# Altium schematic sheet [12]-Valve-Control.SchDoc
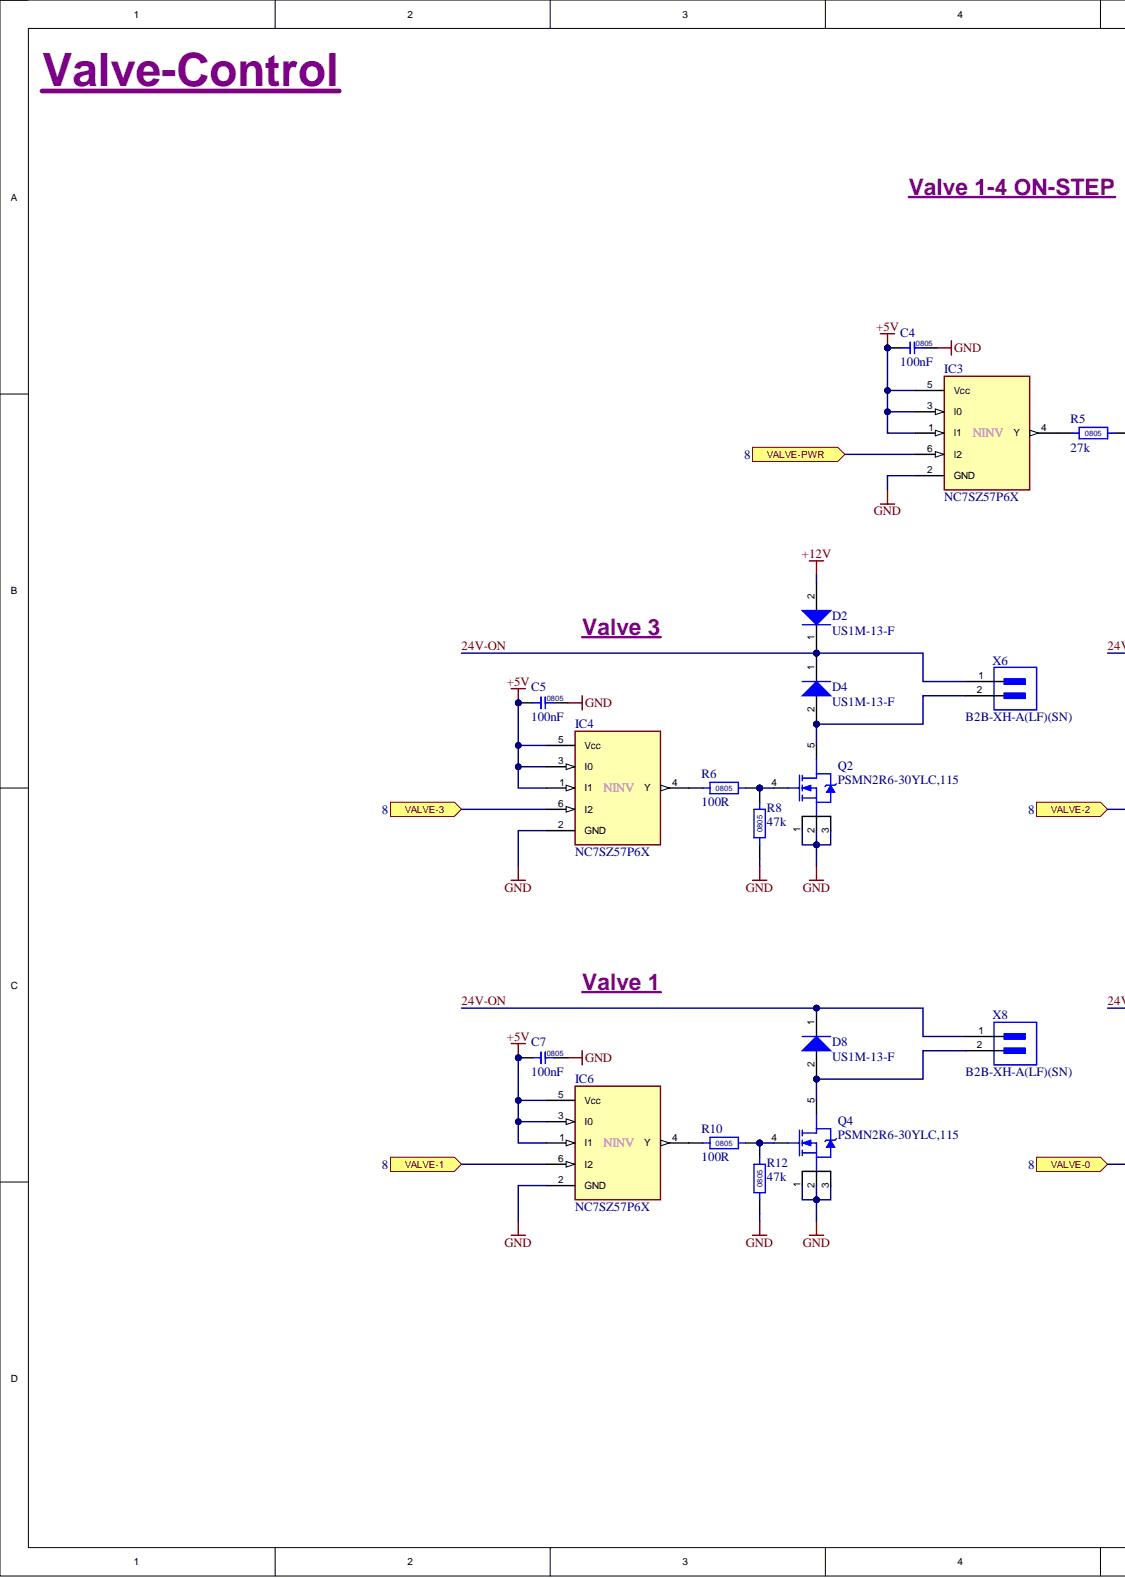
[diagram p0: [12]-Valve-Control.SchDoc, left]
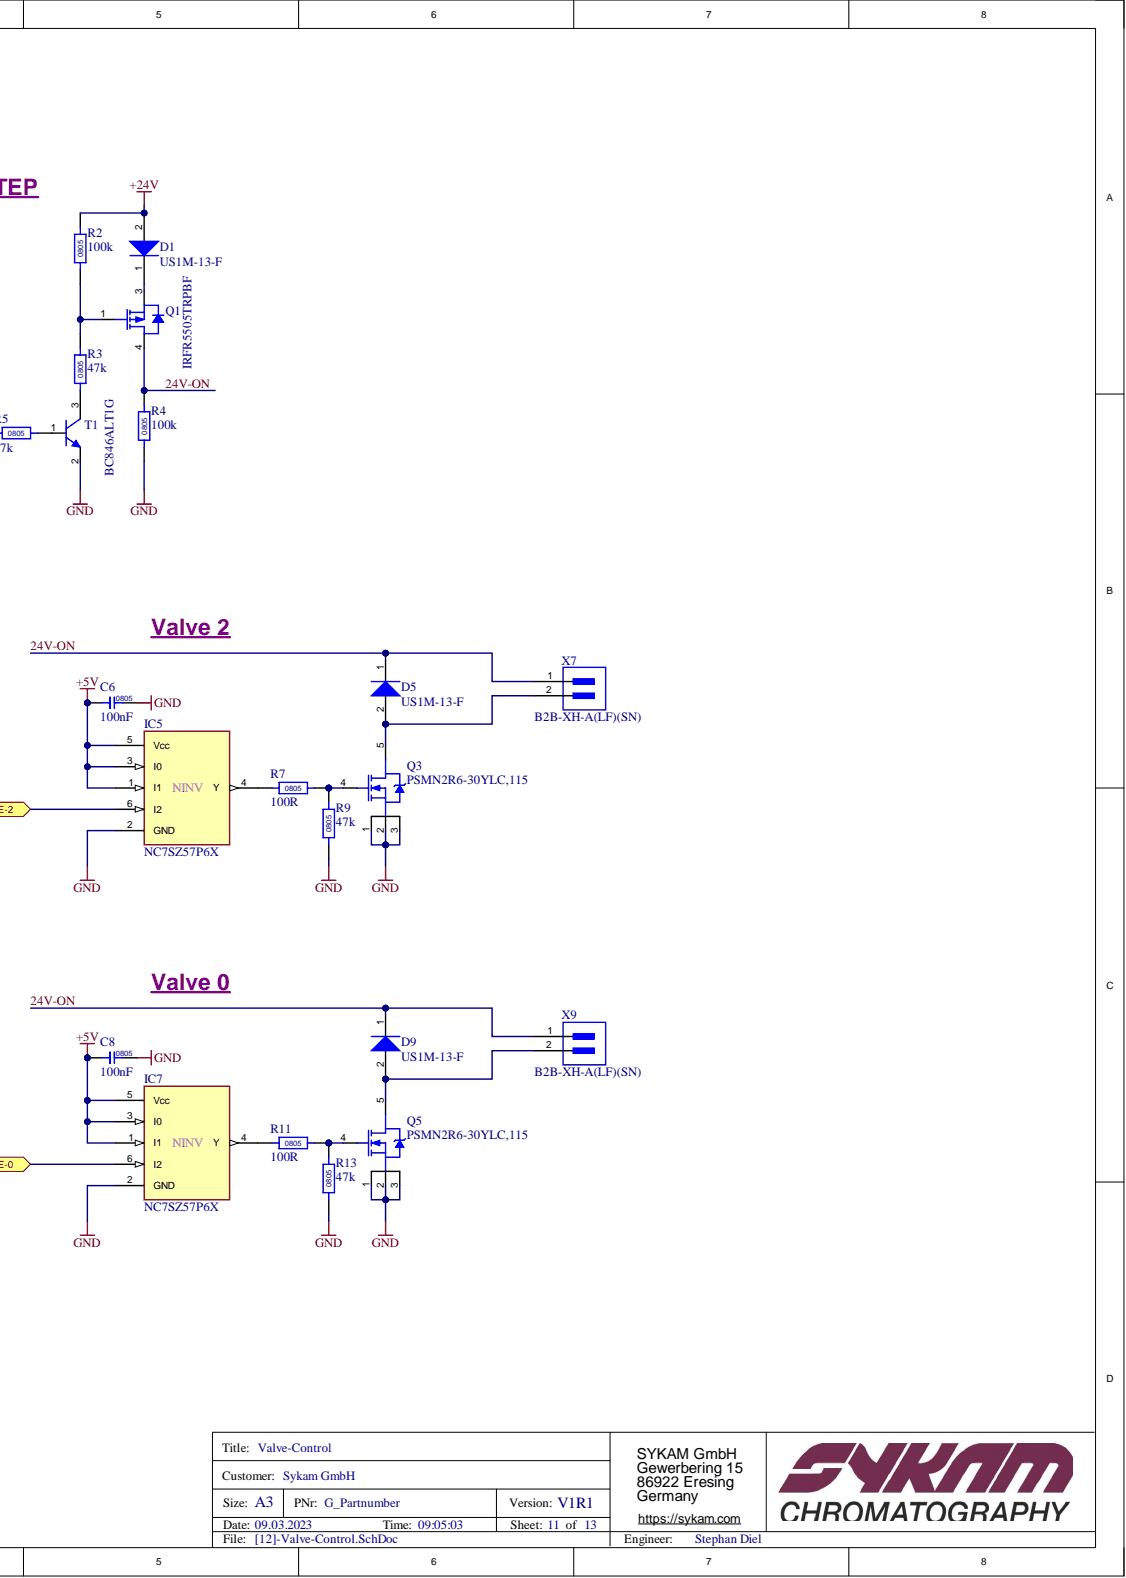
[diagram p1: [12]-Valve-Control.SchDoc, right]

## Parts
C4  100nF  Case Code (Imperial): 0805  [C0805]
C5  100nF  Case Code (Imperial): 0805  [C0805]
C6  100nF  Case Code (Imperial): 0805  [C0805]
C7  100nF  Case Code (Imperial): 0805  [C0805]
C8  100nF  Case Code (Imperial): 0805  [C0805]
D1  US1M-13-F  [US1M-SMA-2_A_V]
D2  US1M-13-F  [US1M-SMA-2_A_V]
D4  US1M-13-F  [US1M-SMA-2_A_V]
D5  US1M-13-F  [US1M-SMA-2_A_V]
D8  US1M-13-F  [US1M-SMA-2_A_V]
D9  US1M-13-F  [US1M-SMA-2_A_V]
IC3  NC7SZ57P6X  [NC7SZ57P6X]
IC4  NC7SZ57P6X  [NC7SZ57P6X]
IC5  NC7SZ57P6X  [NC7SZ57P6X]
IC6  NC7SZ57P6X  [NC7SZ57P6X]
IC7  NC7SZ57P6X  [NC7SZ57P6X]
Q1  IRFR5505TRPBF  [PMOS-DPak]
Q2  PSMN2R6-30YLC,115  [PSMN2R6-LFPAK_SOT669]
Q3  PSMN2R6-30YLC,115  [PSMN2R6-LFPAK_SOT669]
Q4  PSMN2R6-30YLC,115  [PSMN2R6-LFPAK_SOT669]
Q5  PSMN2R6-30YLC,115  [PSMN2R6-LFPAK_SOT669]
R2  100k  Case Code (Imperial): 0805  [R0805]
R3  47k  Case Code (Imperial): 0805  [R0805]
R4  100k  Case Code (Imperial): 0805  [R0805]
R5  27k  Case Code (Imperial): 0805  [R0805]
R6  100R  Case Code (Imperial): 0805  [R0805]
R7  100R  Case Code (Imperial): 0805  [R0805]
R8  47k  Case Code (Imperial): 0805  [R0805]
R9  47k  Case Code (Imperial): 0805  [R0805]
R10  100R  Case Code (Imperial): 0805  [R0805]
R11  100R  Case Code (Imperial): 0805  [R0805]
R12  47k  Case Code (Imperial): 0805  [R0805]
R13  47k  Case Code (Imperial): 0805  [R0805]
T1  BC846ALT1G  [ONSC-SOT-23-3-318-08_V]
X6  B2B-XH-A(LF)(SN)  [CON_2p_JST-B2B-XH-A_V]
X7  B2B-XH-A(LF)(SN)  [CON_2p_JST-B2B-XH-A_V]
X8  B2B-XH-A(LF)(SN)  [CON_2p_JST-B2B-XH-A_V]
X9  B2B-XH-A(LF)(SN)  [CON_2p_JST-B2B-XH-A_V]

## Ports
VALVE-0, VALVE-1, VALVE-2, VALVE-3, VALVE-PWR

## Nets
+5V: C4.1 C5.1 C6.1 C7.1 C8.1 IC3.1(I1) IC3.3(I0) IC3.5(Vcc) IC4.1(I1) IC4.3(I0) IC4.5(Vcc) IC5.1(I1) IC5.3(I0) IC5.5(Vcc) IC6.1(I1) IC6.3(I0) IC6.5(Vcc) IC7.1(I1) IC7.3(I0) IC7.5(Vcc)
+12V: D2.2
GND: C4.2 C5.2 C6.2 C7.2 C8.2 IC3.2(GND) IC4.2(GND) IC5.2(GND) IC6.2(GND) IC7.2(GND) Q2.1 Q2.2 Q2.3 Q3.1 Q3.2 Q3.3 Q4.1 Q4.2 Q4.3 Q5.1 Q5.2 Q5.3 R4.1 R8.1 R9.1 R12.1 R13.1 T1.2
24V-ON: D1.2 D2.1 D4.1 D5.1 D8.1 D9.1 Q1.4 R2.2 R4.2 X6.1 X7.1 X8.1 X9.1
VALVE-0: IC7.6(I2) ; port VALVE-0
VALVE-1: IC6.6(I2) ; port VALVE-1
VALVE-2: IC5.6(I2) ; port VALVE-2
VALVE-3: IC4.6(I2) ; port VALVE-3
VALVE-PWR: IC3.6(I2) ; port VALVE-PWR
NetD1_1: D1.1 Q1.3
NetD4_2: D4.2 Q2.5 X6.2
NetD5_2: D5.2 Q3.5 X7.2
NetD8_2: D8.2 Q4.5 X8.2
NetD9_2: D9.2 Q5.5 X9.2
NetIC3_4: IC3.4(Y) R5.1
NetIC4_4: IC4.4(Y) R6.1
NetIC5_4: IC5.4(Y) R7.1
NetIC6_4: IC6.4(Y) R10.1
NetIC7_4: IC7.4(Y) R11.1
NetQ1_1: Q1.1 R2.1 R3.2
NetQ2_4: Q2.4 R6.2 R8.2
NetQ3_4: Q3.4 R7.2 R9.2
NetQ4_4: Q4.4 R10.2 R12.2
NetQ5_4: Q5.4 R11.2 R13.2
NetR3_1: R3.1 T1.3
NetR5_2: R5.2 T1.1

## Library data of the parts
C4-C8 = C_100nF_CC0805KRX7R9BB104: CAP CER 0.1UF 50V X7R 0805; Manufacturer: Yageo; Insulation Resistance: 100GR; Material: Ceramic; PCBChecked: initials after Checked; Tolerance: 10%; Capacitance: 100nF; Case Code (Metric): 2012; Case/Package: 0805; Category: Ceramic Capacitors; Component Kind: Standard; ComponentLink1Description: https://datasheet.ciiva.com/11786/0900766b80d84f1d-11786009.pdf?src-supplier=RSComponents; ComponentLink1URL: https://datasheet.ciiva.com/11786/0900766b80d84f1d-11786009.pdf?src-supplier=RSComponents; ComponentLink2Description: https://datasheet.ciiva.com/11464/upy-gphc-x7r-6-3v-to-50v-8-11464757.pdf?src-supplier=Avnet; ComponentLink2URL: https://datasheet.ciiva.com/11464/upy-gphc-x7r-6-3v-to-50v-8-11464757.pdf?src-supplier=Avnet; ComponentLink3Description: https://datasheet.ciiva.com/28450/1489800-28450805.pdf?src-supplier=Element14; ComponentLink3URL: https://datasheet.ciiva.com/28450/1489800-28450805.pdf?src-supplier=Element14; ComponentLink4Description: https://datasheet.ciiva.com/155/mlccorderingcode-155929.pdf?src-supplier=Digi-Key; ComponentLink4URL: https://datasheet.ciiva.com/155/mlccorderingcode-155929.pdf?src-supplier=Digi-Key; ComponentLink5Description: https://datasheet.ciiva.com/155/mlccorderingcode-155930.pdf?src-supplier=Digi-Key; ComponentLink5URL: https://datasheet.ciiva.com/155/mlccorderingcode-155930.pdf?src-supplier=Digi-Key; ComponentLink6Description: https://datasheet.ciiva.com/155/mlccorderingcode-155931.pdf?src-supplier=Digi-Key; ComponentLink6URL: https://datasheet.ciiva.com/155/mlccorderingcode-155931.pdf?src-supplier=Digi-Key; ComponentLink7Description: https://datasheet.ciiva.com/46393/1734573-46393307.pdf?src-supplier=Element14; ComponentLink7URL: https://datasheet.ciiva.com/46393/1734573-46393307.pdf?src-supplier=Element14; ComponentLink8Description: https://datasheet.ciiva.com/16625/upy-gphc-x7r-6-3v-to-50v-8-16625549.pdf?src-supplier=Avnet+Europe; ComponentLink8URL: https://datasheet.ciiva.com/16625/upy-gphc-x7r-6-3v-to-50v-8-16625549.pdf?src-supplier=Avnet+Europe; ComponentLink9Description: https://datasheet.ciiva.com/29242/445210-da-01-en-kerko-chip-0805-x7r-0-1uf-10proz-50vg-29242074.pdf?src-supplier=Conrad; ComponentLink9URL: https://datasheet.ciiva.com/29242/445210-da-01-en-kerko-chip-0805-x7r-0-1uf-10proz-50vg-29242074.pdf?src-supplier=Conrad; Depth: 1.25mm; Dielectric: X7R; Halogen Free: Halogen Free; Height: 0.85mm; IPC7352C: done; Lead Free: Lead Free; Length: 2mm; Manufacturer Part Number: CC0805KRX7R9BB104; Max Operating Temperature: 125°C; Min Operating Temperature: -55°C; Mount: Surface Mount; Number of Pins: 2; Package Quantity: 1; Packaging: Tape and Reel; Radiation Hardening: No; REACH SVHC: No SVHC; RoHS: Yes; Stock 1: 7118979; Supplier 1: Digi-Key; Supplier Part Number 1: 311-1140-6-ND; Termination: SMD/SMT; Thickness: 0.033inch; Voltage: 50V; Voltage Rating: 50V; Width: 0.049inch; Library Name: ToradexMainBoard-V0I1.SCHLIB; SCHChecked: Initials after checked
D1 D2 D4 D5 D8 D9 = D_US1M-13-F: Diode Switching 1KV 1A 2-Pin SMA T/R; Manufacturer: Diodes; Capacitance: 10pF; Element Configuration: Single; Forward Voltage: 1.7V; Max Forward Surge Current (Ifsm): 30A; Max Reverse Current: 5uA; Polarity: Standard; Recovery Time: 75ns; Average Rectified Current: 1A; Case/Package: SMA; Category: Rectifier Diodes; Component Kind: Standard; ComponentLink1Description: https://datasheet.ciiva.com/27052/1542696-27052440.pdf?src-supplier=Jameco; ComponentLink1URL: https://datasheet.ciiva.com/27052/1542696-27052440.pdf?src-supplier=Jameco; ComponentLink2Description: https://datasheet.ciiva.com/4454/ds16008-60440-4454096.pdf?src-supplier=Mouser; ComponentLink2URL: https://datasheet.ciiva.com/4454/ds16008-60440-4454096.pdf?src-supplier=Mouser; ComponentLink3Description: https://datasheet.ciiva.com/917/ds16008-917195.pdf?src-supplier=Digi-Key; ComponentLink3URL: https://datasheet.ciiva.com/917/ds16008-917195.pdf?src-supplier=Digi-Key; ComponentLink4Description: https://datasheet.ciiva.com/917/ds16008-917196.pdf?src-supplier=Digi-Key; ComponentLink4URL: https://datasheet.ciiva.com/917/ds16008-917196.pdf?src-supplier=Digi-Key; ComponentLink5Description: https://datasheet.ciiva.com/22695/1906610-22695853.pdf?src-supplier=Element14; ComponentLink5URL: https://datasheet.ciiva.com/22695/1906610-22695853.pdf?src-supplier=Element14; ComponentLink6Description: https://datasheet.ciiva.com/8301/ds16008-8301519.pdf?src-supplier=Avnet; ComponentLink6URL: https://datasheet.ciiva.com/8301/ds16008-8301519.pdf?src-supplier=Avnet; ComponentLink7Description: https://datasheet.ciiva.com/8303/ds16008-8303103.pdf?src-supplier=Avnet; ComponentLink7URL: https://datasheet.ciiva.com/8303/ds16008-8303103.pdf?src-supplier=Avnet; Contact Plating: Tin,Matte; Current Rating: 1A; Forward Current: 1A; Height: 2.1mm; Lead Free: Lead Free; Length: 4.6mm; Manufacturer Part Number: US1M-13-F; Max Operating Temperature: 150°C; Max Repetitive Reverse Voltage (Vrrm): 1kV; Max Reverse Voltage (DC): 1kV; Max Surge Current: 30A; Min Operating Temperature: -65°C; Mount: Surface Mount; Number of Pins: 2; Output Current: 1A; Package Quantity: 5000; Packaging: Tape and Reel; Peak Non-Repetitive Surge Current: 30A; Pricing 1: 5=0,29300, 25=0,21800, 100=0,14200, 250=0,12400, 500=0,10500, 1000=0,08140, 5000=0,07050 (EUR); Radiation Hardening: No; REACH SVHC: No SVHC; Reverse Recovery Time: 75ns; Reverse Voltage (DC): 1kV; RoHS: Yes; Stock 1: 15876; Supplier 1: Farnell; Supplier Part Number 1: 1858603; Termination: SMD/SMT; Voltage Rating (DC): 1kV; Weight: 0.002258oz; Width: 2.92mm; Library Name: ToradexMainBoard-V0I1.SCHLIB
IC3-IC7 = IC_NC7SZ57P6X: FAIRCHILD SEMICONDUCTOR         NC7SZ57P6X.            Multi-Function Logic Gate, Configurable, Ultra High Speed, 3 Input, 32 mA, 1.65V to 5.5V, SC-70-6; Manufacturer: ON Semiconductor / Fairchild; High Level Output Current: -32mA; Logic Function: Multi Function; Low Level Output Current: 32mA; Number of Circuits: 1; Number of Inputs: 2; PCBChecked: initials after Checked; Propagation Delay: 14.5ns; Quiescent Current: 1uA; Schmitt Trigger Input: Yes; Case/Package: SC; Category: Logic Gates; Component Kind: Standard; ComponentLink1Description: https://datasheet.ciiva.com/34301/874445-34301638.pdf?src-supplier=Jameco; ComponentLink1URL: https://datasheet.ciiva.com/34301/874445-34301638.pdf?src-supplier=Jameco; ComponentLink2Description: https://datasheet.ciiva.com/25628/0900766b80f9b61c-25628492.pdf?src-supplier=RSComponents; ComponentLink2URL: https://datasheet.ciiva.com/25628/0900766b80f9b61c-25628492.pdf?src-supplier=RSComponents; ComponentLink3Description: https://datasheet.ciiva.com/15090/getdatasheetpartid-53023-15090335.pdf?src-supplier=Verical; ComponentLink3URL: https://datasheet.ciiva.com/15090/getdatasheetpartid-53023-15090335.pdf?src-supplier=Verical; ComponentLink4Description: https://datasheet.ciiva.com/1880/nc7sz57-1880020.pdf?src-supplier=Digi-Key; ComponentLink4URL: https://datasheet.ciiva.com/1880/nc7sz57-1880020.pdf?src-supplier=Digi-Key; ComponentLink5Description: https://datasheet.ciiva.com/8249/nc7sz57-8249558.pdf?src-supplier=Avnet; ComponentLink5URL: https://datasheet.ciiva.com/8249/nc7sz57-8249558.pdf?src-supplier=Avnet; ComponentLink6Description: https://datasheet.ciiva.com/16503/nc7sz57-16503560.pdf?src-supplier=Avnet+Asia; ComponentLink6URL: https://datasheet.ciiva.com/16503/nc7sz57-16503560.pdf?src-supplier=Avnet+Asia; ComponentLink7Description: https://datasheet.ciiva.com/1880/nc7sz57-1880021.pdf?src-supplier=Digi-Key; ComponentLink7URL: https://datasheet.ciiva.com/1880/nc7sz57-1880021.pdf?src-supplier=Digi-Key; ComponentLink8Description: https://datasheet.ciiva.com/7970/1727673-7970677.pdf?src-supplier=Element14; ComponentLink8URL: https://datasheet.ciiva.com/7970/1727673-7970677.pdf?src-supplier=Element14; ComponentLink9Description: https://datasheet.ciiva.com/1880/nc7sz57-1880022.pdf?src-supplier=Digi-Key; ComponentLink9URL: https://datasheet.ciiva.com/1880/nc7sz57-1880022.pdf?src-supplier=Digi-Key; ComponentLink10Description: https://datasheet.ciiva.com/16977/nc7sz57-16977945.pdf?src-supplier=Future+Electronics; ComponentLink10URL: https://datasheet.ciiva.com/16977/nc7sz57-16977945.pdf?src-supplier=Future+Electronics; Height: 1mm; IPC7352C: done; Lead Free: Lead Free; Length: 2mm; Manufacturer Part Number: NC7SZ57P6X; Max Operating Temperature: 85°C; Max Supply Voltage: 5.5V; Min Operating Temperature: -40°C; Min Supply Voltage: 1.65V; Mount: Surface Mount; Number of Elements: 1; Number of Gates: 1; Number of Outputs: 1; Number of Pins: 6; Number of Terminals: 6; Output Current: 32mA; Package Quantity: 3000; Packaging: Tape and Reel; Radiation Hardening: No; REACH SVHC: No SVHC; RoHS: Yes; Stock 1: 16277; Supplier 1: Digi-Key; Supplier Part Number 1: NC7SZ57P6XCT-ND; Turn-On Delay Time: 14.5ns; Weight: 0.028g; Width: 1.25mm; Library Name: ToradexMainBoard-V0I1.SCHLIB; SCHChecked: Initials after checked
Q1 = Q_PMOS_IRFR5505TRPBF: MOSFET, P CH, -55V, -18A, TO-252AA-3; Transistor Polarity:P Channel; Continuous Drain Current Id:-18A; Drain Source Voltage Vds:-55V; On Resistance Rds(on):0.11ohm; Rds(on) Test Voltage Vgs:-10V; Threshold Voltage Vgs:-4V; Power Dissipation Pd:57W; Transistor Case Style:TO-252AA; No. of Pins:3; Operating Temperature Max:150°C; MSL:MSL 1 - Unlimited; SVHC:No SVHC (15-Jun-2015); Manufacturer: International Rectifier; Continuous Drain Current (ID): 18A; Drain to Source Resistance: 110mR; Drain to Source Voltage (Vdss): 55V; Element Configuration: Single; Fall Time: 16ns; Gate to Source Voltage (Vgs): 20V; Input Capacitance: 650pF; Max Power Dissipation: 57W; Nominal Vgs: -4V; Number of Elements: 1; Rise Time: 28ns; Turn-Off Delay Time: 20ns; Turn-On Delay Time: 12ns; Case/Package: DPAK; Category: MOSFETs; Component Kind: Standard; ComponentLink1Description: https://datasheet.ciiva.com/6382/irfr5505pbf-6382422.pdf?src-supplier=Digi-Key; ComponentLink1URL: https://datasheet.ciiva.com/6382/irfr5505pbf-6382422.pdf?src-supplier=Digi-Key; ComponentLink2Description: https://datasheet.ciiva.com/7798/irfr5505-7798823.pdf?src-supplier=Element14; ComponentLink2URL: https://datasheet.ciiva.com/7798/irfr5505-7798823.pdf?src-supplier=Element14; ComponentLink3Description: https://datasheet.ciiva.com/6382/irfr5505pbf-6382424.pdf?src-supplier=Digi-Key; ComponentLink3URL: https://datasheet.ciiva.com/6382/irfr5505pbf-6382424.pdf?src-supplier=Digi-Key; ComponentLink4Description: https://datasheet.ciiva.com/16222/irfr5505pbf-16222552.pdf?src-supplier=Future+Electronics; ComponentLink4URL: https://datasheet.ciiva.com/16222/irfr5505pbf-16222552.pdf?src-supplier=Future+Electronics; ComponentLink5Description: https://datasheet.ciiva.com/6382/irfr5505pbf-6382428.pdf?src-supplier=Digi-Key; ComponentLink5URL: https://datasheet.ciiva.com/6382/irfr5505pbf-6382428.pdf?src-supplier=Digi-Key; Current Rating: -18A; Drain to Source Breakdown Voltage: -55V; Height: 2.39mm; Lead Free: Lead Free; Length: 6.73mm; Manufacturer Part Number: IRFR5505TRPBF; Max Operating Temperature: 150°C; Min Operating Temperature: -55°C; Mount: Surface Mount; Number of Pins: 3; On-State Resistance: 110mR; Package Quantity: 1; Packaging: Tape and Reel; Power Dissipation: 57W; Pricing 1: 1=0,89800, 10=0,68100, 100=0,49300, 250=0,45800, 500=0,42300, 1000=0,32500, 5000=0,29600 (EUR); Radiation Hardening: No; Rds On Max: 110mR; REACH SVHC: No SVHC; RoHS: Yes; Stock 1: 10190; Supplier 1: Farnell; Supplier Part Number 1: 2468041; Threshold Voltage: -4V; Voltage Rating (DC): -55V; Width: 6.22mm; Library Name: ToradexMainBoard-V0I1.SCHLIB
Q2-Q5 = Q_NMOS_PSMN2R6-30YLC,115: PSMN2R6-30YLC - N-channel 30 V 2.8 mΩ logic level MOSFET in LFPAK using NextPower technology; Manufacturer: Nexperia; Component Kind: Standard; ComponentLink1Description: http://datasheet.octopart.com/PSMN2R6-30YLC%2C115-Nexperia-datasheet-87582230.pdf?src-supplier=Nexperia; ComponentLink1URL: http://datasheet.octopart.com/PSMN2R6-30YLC%2C115-Nexperia-datasheet-87582230.pdf?src-supplier=Nexperia; ComponentLink2Description: http://datasheet.octopart.com/PSMN2R6-30YLC%2C115-Nexperia-datasheet-10409835.pdf?src-supplier=Upverter; ComponentLink2URL: http://datasheet.octopart.com/PSMN2R6-30YLC%2C115-Nexperia-datasheet-10409835.pdf?src-supplier=Upverter; ComponentLink3Description: http://datasheet.octopart.com/PSMN2R6-30YLC%2C115-Nexperia-datasheet-78818593.pdf?src-supplier=Future+Electronics; ComponentLink3URL: http://datasheet.octopart.com/PSMN2R6-30YLC%2C115-Nexperia-datasheet-78818593.pdf?src-supplier=Future+Electronics; ComponentLink4Description: http://datasheet.octopart.com/PSMN2R6-30YLC%2C115-NXP-Semiconductors-datasheet-11789213.pdf?src-supplier=Arrow+Electronics; ComponentLink4URL: http://datasheet.octopart.com/PSMN2R6-30YLC%2C115-NXP-Semiconductors-datasheet-11789213.pdf?src-supplier=Arrow+Electronics; Manufacturer Part Number: PSMN2R6-30YLC,115; Pricing 1: 1=0,65800, 10=0,52700, 100=0,41600, 250=0,38700, 500=0,35600, 1000=0,29800, 5000=0,28500 (EUR); Stock 1: 1500; Supplier 1: Farnell; Supplier Part Number 1: 3440108; Library Name: ToradexMainBoard-V0I1.SCHLIB
R2 R4 = R_100k_RC0805FR-07100KL: RES SMD 100K OHM 1% 1/8W 0805; Manufacturer: Yageo; Max Power Dissipation: 125mW; PCBChecked: initials after Checked; Resistance: 100kR; Temperature Coefficient: 100ppm/°C; Voltage Rating: 150V; Case Code (Metric): 2012; Case/Package: 0805; Category: Chip SMD Resistors; Component Kind: Standard; ComponentLink1Description: https://datasheet.ciiva.com/11808/0900766b8106beab-11808858.pdf?src-supplier=RSComponents; ComponentLink1URL: https://datasheet.ciiva.com/11808/0900766b8106beab-11808858.pdf?src-supplier=RSComponents; ComponentLink2Description: https://datasheet.ciiva.com/2457/pyu-r-int-thick-7-2457017.pdf?src-supplier=Digi-Key; ComponentLink2URL: https://datasheet.ciiva.com/2457/pyu-r-int-thick-7-2457017.pdf?src-supplier=Digi-Key; ComponentLink3Description: https://datasheet.ciiva.com/12667/rc0805-12667001.pdf?src-supplier=Mouser; ComponentLink3URL: https://datasheet.ciiva.com/12667/rc0805-12667001.pdf?src-supplier=Mouser; ComponentLink4Description: https://datasheet.ciiva.com/2457/pyu-r-int-thick-7-2457018.pdf?src-supplier=Digi-Key; ComponentLink4URL: https://datasheet.ciiva.com/2457/pyu-r-int-thick-7-2457018.pdf?src-supplier=Digi-Key; ComponentLink5Description: https://datasheet.ciiva.com/16747/rc0805-16747226.pdf?src-supplier=Future+Electronics; ComponentLink5URL: https://datasheet.ciiva.com/16747/rc0805-16747226.pdf?src-supplier=Future+Electronics; ComponentLink6Description: https://datasheet.ciiva.com/2457/pyu-r-int-thick-7-2457019.pdf?src-supplier=Digi-Key; ComponentLink6URL: https://datasheet.ciiva.com/2457/pyu-r-int-thick-7-2457019.pdf?src-supplier=Digi-Key; ComponentLink7Description: https://datasheet.ciiva.com/7660/38627-7660796.pdf?src-supplier=Element14; ComponentLink7URL: https://datasheet.ciiva.com/7660/38627-7660796.pdf?src-supplier=Element14; ComponentLink8Description: https://datasheet.ciiva.com/7941/1697719-7941676.pdf?src-supplier=Element14; ComponentLink8URL: https://datasheet.ciiva.com/7941/1697719-7941676.pdf?src-supplier=Element14; ComponentLink9Description: https://datasheet.ciiva.com/7941/1686128-7941677.pdf?src-supplier=Element14; ComponentLink9URL: https://datasheet.ciiva.com/7941/1686128-7941677.pdf?src-supplier=Element14; ComponentLink10Description: https://datasheet.ciiva.com/7941/1697719-7941678.pdf?src-supplier=Element14; ComponentLink10URL: https://datasheet.ciiva.com/7941/1697719-7941678.pdf?src-supplier=Element14; ComponentLink11Description: https://datasheet.ciiva.com/22747/444164-22747534.pdf?src-supplier=Element14; ComponentLink11URL: https://datasheet.ciiva.com/22747/444164-22747534.pdf?src-supplier=Element14; Composition: Thick Film; Depth: 1.25mm; Features: Moisture Resistant; Height: 0.024inch; IPC7352C: done; Lead Free: Lead Free; Lead Pitch: 0.4mm; Length: 2mm; Manufacturer Part Number: RC0805FR-07100KL; Max Operating Temperature: 155°C; Military Standard: Not; Min Operating Temperature: -55°C; Number of Pins: 2; Number of Terminations: 2; Package Quantity: 1; Packaging: Tape and Reel; Power Rating: 125mW; REACH SVHC: Unknown; RoHS: Yes; Stock 1: 6543127; Supplier 1: Digi-Key; Supplier Part Number 1: 311-100KCRCT-ND; Termination: SMD/SMT; Tolerance: 1%; Voltage Rating (DC): 150V; Width: 0.049inch; Library Name: ToradexMainBoard-V0I1.SCHLIB; SCHChecked: Initials after checked
R3 R8 R9 R12 R13 = R_47k_CRCW080547K0FKEA: SMD Resistor, Thick film 47 kOhm,  ±  1 %, 0805, CRCW080547K0FKEA, Vishay; Max Power Dissipation: 125mW; Resistance: 47kR; Temperature Coefficient: 100ppm/°C; Tolerance: 1%; Voltage Rating: 150V; Case Code (Metric): 2012; Case/Package: 0805; Category: Chip SMD Resistors; Component Kind: Standard; ComponentLink1Description: https://datasheet.ciiva.com/11809/0900766b80d98b26-11809181.pdf?src-supplier=RSComponents; ComponentLink1URL: https://datasheet.ciiva.com/11809/0900766b80d98b26-11809181.pdf?src-supplier=RSComponents; ComponentLink2Description: https://datasheet.ciiva.com/2514/dcrcwe3-2514312.pdf?src-supplier=Digi-Key; ComponentLink2URL: https://datasheet.ciiva.com/2514/dcrcwe3-2514312.pdf?src-supplier=Digi-Key; ComponentLink3Description: https://datasheet.ciiva.com/16204/dcrcwe3-16204264.pdf?src-supplier=Future+Electronics; ComponentLink3URL: https://datasheet.ciiva.com/16204/dcrcwe3-16204264.pdf?src-supplier=Future+Electronics; ComponentLink4Description: https://datasheet.ciiva.com/26314/fld-crcw-e3-data-en-26314584.pdf?src-supplier=Distrelec; ComponentLink4URL: https://datasheet.ciiva.com/26314/fld-crcw-e3-data-en-26314584.pdf?src-supplier=Distrelec; ComponentLink5Description: https://datasheet.ciiva.com/16723/70201119-16723909.pdf?src-supplier=Allied+Electronics; ComponentLink5URL: https://datasheet.ciiva.com/16723/70201119-16723909.pdf?src-supplier=Allied+Electronics; ComponentLink6Description: https://datasheet.ciiva.com/2514/dcrcwe3-2514311.pdf?src-supplier=Digi-Key; ComponentLink6URL: https://datasheet.ciiva.com/2514/dcrcwe3-2514311.pdf?src-supplier=Digi-Key; ComponentLink7Description: https://datasheet.ciiva.com/7777/1720486-7777577.pdf?src-supplier=Element14; ComponentLink7URL: https://datasheet.ciiva.com/7777/1720486-7777577.pdf?src-supplier=Element14; ComponentLink8Description: https://datasheet.ciiva.com/9006/dcrcwe3-9006477.pdf?src-supplier=Avnet; ComponentLink8URL: https://datasheet.ciiva.com/9006/dcrcwe3-9006477.pdf?src-supplier=Avnet; ComponentLink9Description: https://datasheet.ciiva.com/17178/dcrcwe3-17178445.pdf?src-supplier=Avnet+Europe; ComponentLink9URL: https://datasheet.ciiva.com/17178/dcrcwe3-17178445.pdf?src-supplier=Avnet+Europe; ComponentLink10Description: https://datasheet.ciiva.com/7777/1697337-7777406.pdf?src-supplier=Element14; ComponentLink10URL: https://datasheet.ciiva.com/7777/1697337-7777406.pdf?src-supplier=Element14; Contact Plating: Tin; Depth: 1.25mm; Features: Automotive AEC-Q200; Height: 0.45mm; Lead Free: Lead Free; Length: 2mm; Manufacturer: Vishay; Manufacturer Part Number: CRCW080547K0FKEA; Max Operating Temperature: 155°C; Military Standard: Not; Min Operating Temperature: -55°C; Number of Terminations: 2; Package Quantity: 10; Packaging: Tape and Reel; Power Rating: 125mW; Pricing 1: 10=0,07230, 100=0,02890, 500=0,02010, 1000=0,01130, 2500=0,00890, 5000=0,00530, 25000=0,00490 (EUR); Radiation Hardening: No; REACH SVHC: Unknown; Resistor Type: Thick Film; RoHS: Yes; Stock 1: 27525; Supplier 1: Farnell; Supplier Part Number 1: 1469929; Termination: SMD/SMT; Voltage Rating (DC): 150V; Weight: 0.000194oz; Width: 1.25mm; Working Voltage: 150V; Library Name: ToradexMainBoard-V0I1.SCHLIB
R5 = R_27k_RC0805FR-0727KL: Surface Mount Thick Film Resistor, RC Series, 27 kohm, 125 mW, - 1%, 150 V, 0805 [2012 Metric]; Manufacturer: Yageo; Max Power Dissipation: 125mW; Resistance: 27kR; Temperature Coefficient: 100ppm/°C; Voltage Rating: 150V; Case Code (Metric): 2012; Case/Package: 0805; Category: Chip SMD Resistors; Component Kind: Standard; ComponentLink1Description: https://datasheet.ciiva.com/11809/0900766b8106beab-11809381.pdf?src-supplier=RSComponents; ComponentLink1URL: https://datasheet.ciiva.com/11809/0900766b8106beab-11809381.pdf?src-supplier=RSComponents; ComponentLink2Description: https://datasheet.ciiva.com/2457/pyu-r-int-thick-7-2457986.pdf?src-supplier=Digi-Key; ComponentLink2URL: https://datasheet.ciiva.com/2457/pyu-r-int-thick-7-2457986.pdf?src-supplier=Digi-Key; ComponentLink3Description: https://datasheet.ciiva.com/2457/pyu-r-int-thick-7-2457988.pdf?src-supplier=Digi-Key; ComponentLink3URL: https://datasheet.ciiva.com/2457/pyu-r-int-thick-7-2457988.pdf?src-supplier=Digi-Key; ComponentLink4Description: https://datasheet.ciiva.com/7941/444164-7941751.pdf?src-supplier=Element14; ComponentLink4URL: https://datasheet.ciiva.com/7941/444164-7941751.pdf?src-supplier=Element14; ComponentLink5Description: https://datasheet.ciiva.com/2457/pyu-r-int-thick-7-2457987.pdf?src-supplier=Digi-Key; ComponentLink5URL: https://datasheet.ciiva.com/2457/pyu-r-int-thick-7-2457987.pdf?src-supplier=Digi-Key; ComponentLink6Description: https://datasheet.ciiva.com/7941/444164-7941750.pdf?src-supplier=Element14; ComponentLink6URL: https://datasheet.ciiva.com/7941/444164-7941750.pdf?src-supplier=Element14; Composition: Thick Film; Depth: 1.25mm; Features: Moisture Resistant; Height: 0.5mm; Lead Free: Lead Free; Length: 2mm; Manufacturer Part Number: RC0805FR-0727KL; Max Operating Temperature: 155°C; Military Standard: Not; Min Operating Temperature: -55°C; Number of Terminations: 2; Package Quantity: 1; Packaging: Tape and Reel; Power Rating: 125mW; Pricing 1: 1=0,10000, 10=0,04000, 100=0,01630, 1000=0,00731, 2500=0,00635 (USD); REACH SVHC: Unknown; RoHS: Yes; Stock 1: 307907; Supplier 1: Digi-Key; Supplier Part Number 1: 311-27.0KCRCT-ND; Termination: SMD/SMT; Tolerance: 1%; Width: 0.049inch; Library Name: ToradexMainBoard-V0I1.SCHLIB
R6 R7 R10 R11 = R_100R_RC0805FR-07100RL: Res Thick Film 0805 100 Ohm 1% 0.125W(1/8W) ±100ppm/C Epoxy SMD T/R; Manufacturer: Yageo; Application(s): HIGH RELIABILITY; Max Power Dissipation: 125mW; Temperature Coefficient: 100ppm/°C; Voltage Rating: 150V; Case Code (Metric): 2012; Case/Package: 0805; Category: Chip SMD Resistors; Component Kind: Standard; ComponentLink1Description: https://datasheet.ciiva.com/7941/1697719-7941680.pdf?src-supplier=Element14; ComponentLink1URL: https://datasheet.ciiva.com/7941/1697719-7941680.pdf?src-supplier=Element14; ComponentLink2Description: https://datasheet.ciiva.com/11808/0900766b8106beab-11808877.pdf?src-supplier=RSComponents; ComponentLink2URL: https://datasheet.ciiva.com/11808/0900766b8106beab-11808877.pdf?src-supplier=RSComponents; ComponentLink3Description: https://datasheet.ciiva.com/17082/rc0805-17082697.pdf?src-supplier=Future+Electronics; ComponentLink3URL: https://datasheet.ciiva.com/17082/rc0805-17082697.pdf?src-supplier=Future+Electronics; ComponentLink4Description: https://datasheet.ciiva.com/46447/444164-46447562.pdf?src-supplier=Element14; ComponentLink4URL: https://datasheet.ciiva.com/46447/444164-46447562.pdf?src-supplier=Element14; ComponentLink5Description: https://datasheet.ciiva.com/2456/pyu-r-int-thick-7-2456987.pdf?src-supplier=Digi-Key; ComponentLink5URL: https://datasheet.ciiva.com/2456/pyu-r-int-thick-7-2456987.pdf?src-supplier=Digi-Key; ComponentLink6Description: https://datasheet.ciiva.com/2456/pyu-r-int-thick-7-2456988.pdf?src-supplier=Digi-Key; ComponentLink6URL: https://datasheet.ciiva.com/2456/pyu-r-int-thick-7-2456988.pdf?src-supplier=Digi-Key; ComponentLink7Description: https://datasheet.ciiva.com/2456/pyu-r-int-thick-7-2456989.pdf?src-supplier=Digi-Key; ComponentLink7URL: https://datasheet.ciiva.com/2456/pyu-r-int-thick-7-2456989.pdf?src-supplier=Digi-Key; ComponentLink8Description: https://datasheet.ciiva.com/7660/38627-7660797.pdf?src-supplier=Element14; ComponentLink8URL: https://datasheet.ciiva.com/7660/38627-7660797.pdf?src-supplier=Element14; ComponentLink9Description: https://datasheet.ciiva.com/7941/1686128-7941679.pdf?src-supplier=Element14; ComponentLink9URL: https://datasheet.ciiva.com/7941/1686128-7941679.pdf?src-supplier=Element14; ComponentLink10Description: https://datasheet.ciiva.com/22747/444164-22747535.pdf?src-supplier=Element14; ComponentLink10URL: https://datasheet.ciiva.com/22747/444164-22747535.pdf?src-supplier=Element14; Depth: 1.25mm; Features: Moisture Resistant; Height: 0.5mm; Lead Free: Lead Free; Lead Pitch: 0.4mm; Length: 2mm; Manufacturer Part Number: RC0805FR-07100RL; Max Operating Temperature: 155°C; Military Standard: Not; Min Operating Temperature: -55°C; Number of Pins: 2; Number of Terminations: 2; Package Quantity: 5000; Packaging: Cut Tape; Power Rating: 125mW; Pricing 1: 5000=0,00660, 25000=0,00480 (EUR); REACH SVHC: Unknown; Resistance: 100R; Resistor Type: Thick Film; RoHS: Yes; RoHS Compliant: Yes; Series: RC; Stock 1: 355000; Supplier 1: Farnell; Supplier Part Number 1: 2421934; Technology: THICK FILM; Termination: SMD/SMT; Tolerance: 1%; Type: General Purpose; Voltage Rating (DC): 150V; Weight: 0.000917oz; Width: 1.25mm; Library Name: ToradexMainBoard-V0I1.SCHLIB
T1 = T_BC846ALT1G: ON SEMICONDUCTOR - BC846ALT1G - BIPOLAR TRANSISTOR, FULL REEL; Collector Base Voltage (VCBO): 80V; Collector Emitter Breakdown Voltage: 65V; Collector Emitter Saturation Voltage: 600mV; Current Rating: 100mA; Element Configuration: Single; Emitter Base Voltage (VEBO): 6V; Frequency: 100MHz; hFE Min: 110; Max Power Dissipation: 300mW; Number of Elements: 1; Polarity: NPN; Case/Package: SOT-23-3; Category: BJTs; Collector Emitter Voltage (VCEO): 65V; Component Kind: Standard; ComponentLink1Description: https://datasheet.ciiva.com/15138/getdatasheetpartid-38432-15138609.pdf?src-supplier=Verical; ComponentLink1URL: https://datasheet.ciiva.com/15138/getdatasheetpartid-38432-15138609.pdf?src-supplier=Verical; ComponentLink2Description: https://datasheet.ciiva.com/976/bc846alt1-d-976374.pdf?src-supplier=Digi-Key; ComponentLink2URL: https://datasheet.ciiva.com/976/bc846alt1-d-976374.pdf?src-supplier=Digi-Key; ComponentLink3Description: https://datasheet.ciiva.com/976/bc846alt1-d-976375.pdf?src-supplier=Digi-Key; ComponentLink3URL: https://datasheet.ciiva.com/976/bc846alt1-d-976375.pdf?src-supplier=Digi-Key; ComponentLink4Description: https://datasheet.ciiva.com/976/bc846alt1-d-976376.pdf?src-supplier=Digi-Key; ComponentLink4URL: https://datasheet.ciiva.com/976/bc846alt1-d-976376.pdf?src-supplier=Digi-Key; ComponentLink5Description: https://datasheet.ciiva.com/22664/1571861-22664104.pdf?src-supplier=Element14; ComponentLink5URL: https://datasheet.ciiva.com/22664/1571861-22664104.pdf?src-supplier=Element14; ComponentLink6Description: https://datasheet.ciiva.com/7972/bc846alt1-d-7972186.pdf?src-supplier=Element14; ComponentLink6URL: https://datasheet.ciiva.com/7972/bc846alt1-d-7972186.pdf?src-supplier=Element14; ComponentLink7Description: https://datasheet.ciiva.com/7972/bc846alt1-d-7972187.pdf?src-supplier=Element14; ComponentLink7URL: https://datasheet.ciiva.com/7972/bc846alt1-d-7972187.pdf?src-supplier=Element14; ComponentLink8Description: https://datasheet.ciiva.com/11197/bc846alt1-d-11197532.pdf?src-supplier=Avnet; ComponentLink8URL: https://datasheet.ciiva.com/11197/bc846alt1-d-11197532.pdf?src-supplier=Avnet; Contact Plating: Tin,Matte; Gain Bandwidth Product: 100MHz; Halogen Free: Halogen Free; Height: 0.94mm; Lead Free: Lead Free; Length: 2.9mm; Manufacturer: ON Semiconductor; Manufacturer Part Number: BC846ALT1G; Max Breakdown Voltage: 65V; Max Collector Current: 100mA; Max Frequency: 100MHz; Max Operating Temperature: 150°C; Min Operating Temperature: -55°C; Number of Pins: 3; Package Quantity: 1; Packaging: Tape and Reel; Power Dissipation: 300mW; Pricing 1: 1=0,12000, 10=0,10900, 25=0,10360, 100=0,04570, 250=0,04384, 500=0,03586, 1000=0,02490 (USD); Radiation Hardening: No; REACH SVHC: No SVHC; RoHS: Yes; Stock 1: 258757; Supplier 1: Digi-Key; Supplier Part Number 1: BC846ALT1GOSCT-ND; Transition Frequency: 100MHz; Voltage Rating (DC): 65V; Width: 1.3mm; Library Name: ToradexMainBoard-V0I1.SCHLIB
X6-X9 = CON_2p_B2B-XH-A(LF)(SN): Conn Shrouded Header (4 Sides) HDR 2 POS 2.5mm Solder ST Thru-Hole Box; Manufacturer: JST; Connector Type: Male Pin; Contact Resistance: 20mR; Current Rating: 3A; Insulation Resistance: 1GR; Max Voltage Rating (AC): 250V; Number of Contacts: 2; Wire/Cable Gauge: 22AWG; Category: FFC / FPC; Color: Natural; Component Kind: Standard; ComponentLink1Description: https://datasheet.ciiva.com/26894/getdatasheetpartid-640357-26894434.pdf?src-supplier=Verical; ComponentLink1URL: https://datasheet.ciiva.com/26894/getdatasheetpartid-640357-26894434.pdf?src-supplier=Verical; ComponentLink2Description: https://datasheet.ciiva.com/11746/0900766b8082653e-11746979.pdf?src-supplier=RSComponents; ComponentLink2URL: https://datasheet.ciiva.com/11746/0900766b8082653e-11746979.pdf?src-supplier=RSComponents; ComponentLink3Description: https://datasheet.ciiva.com/26894/getdatasheetpartid-385291-26894760.pdf?src-supplier=Verical; ComponentLink3URL: https://datasheet.ciiva.com/26894/getdatasheetpartid-385291-26894760.pdf?src-supplier=Verical; ComponentLink4Description: https://datasheet.ciiva.com/8052/5448-8052024.pdf?src-supplier=Element14; ComponentLink4URL: https://datasheet.ciiva.com/8052/5448-8052024.pdf?src-supplier=Element14; ComponentLink5Description: https://datasheet.ciiva.com/20207/523653-20207167.pdf?src-supplier=Rapid+Electronics; ComponentLink5URL: https://datasheet.ciiva.com/20207/523653-20207167.pdf?src-supplier=Rapid+Electronics; Contact Material: Brass; Contact Plating: Tin,Copper,Lead; Depth: 5.75mm; Fastening Type: Detent; Housing Color: White; Housing Material: Polyamide; Lead Free: Lead Free; Lead Pitch: 2.5mm; Length: 7.4mm; Manufacturer Part Number: B2B-XH-A(LF)(SN); Max Current Rating: 3A; Max Operating Temperature: 85°C; Max Voltage Rating (DC): 250V; Min Operating Temperature: -25°C; Mount: Through Hole; Number of Positions: 2; Number of Rows: 1; Orientation: Straight; Packaging: Bulk; Pitch: 2.5mm; Pricing 1: 100=0,06890, 1000=0,06030, 2500=0,05600, 5000=0,05160, 10000=0,05030, 20000=0,04930 (EUR); REACH SVHC: No SVHC; RoHS: Yes; Stock 1: 138104; Supplier 1: Farnell; Supplier Part Number 1: 1516276; Termination: Solder; Voltage Rating: 250V; Voltage Rating (AC): 250V; Library Name: ToradexMainBoard-V0I1.SCHLIB
